# Altium schematic sheet Power Supplies.SchDoc
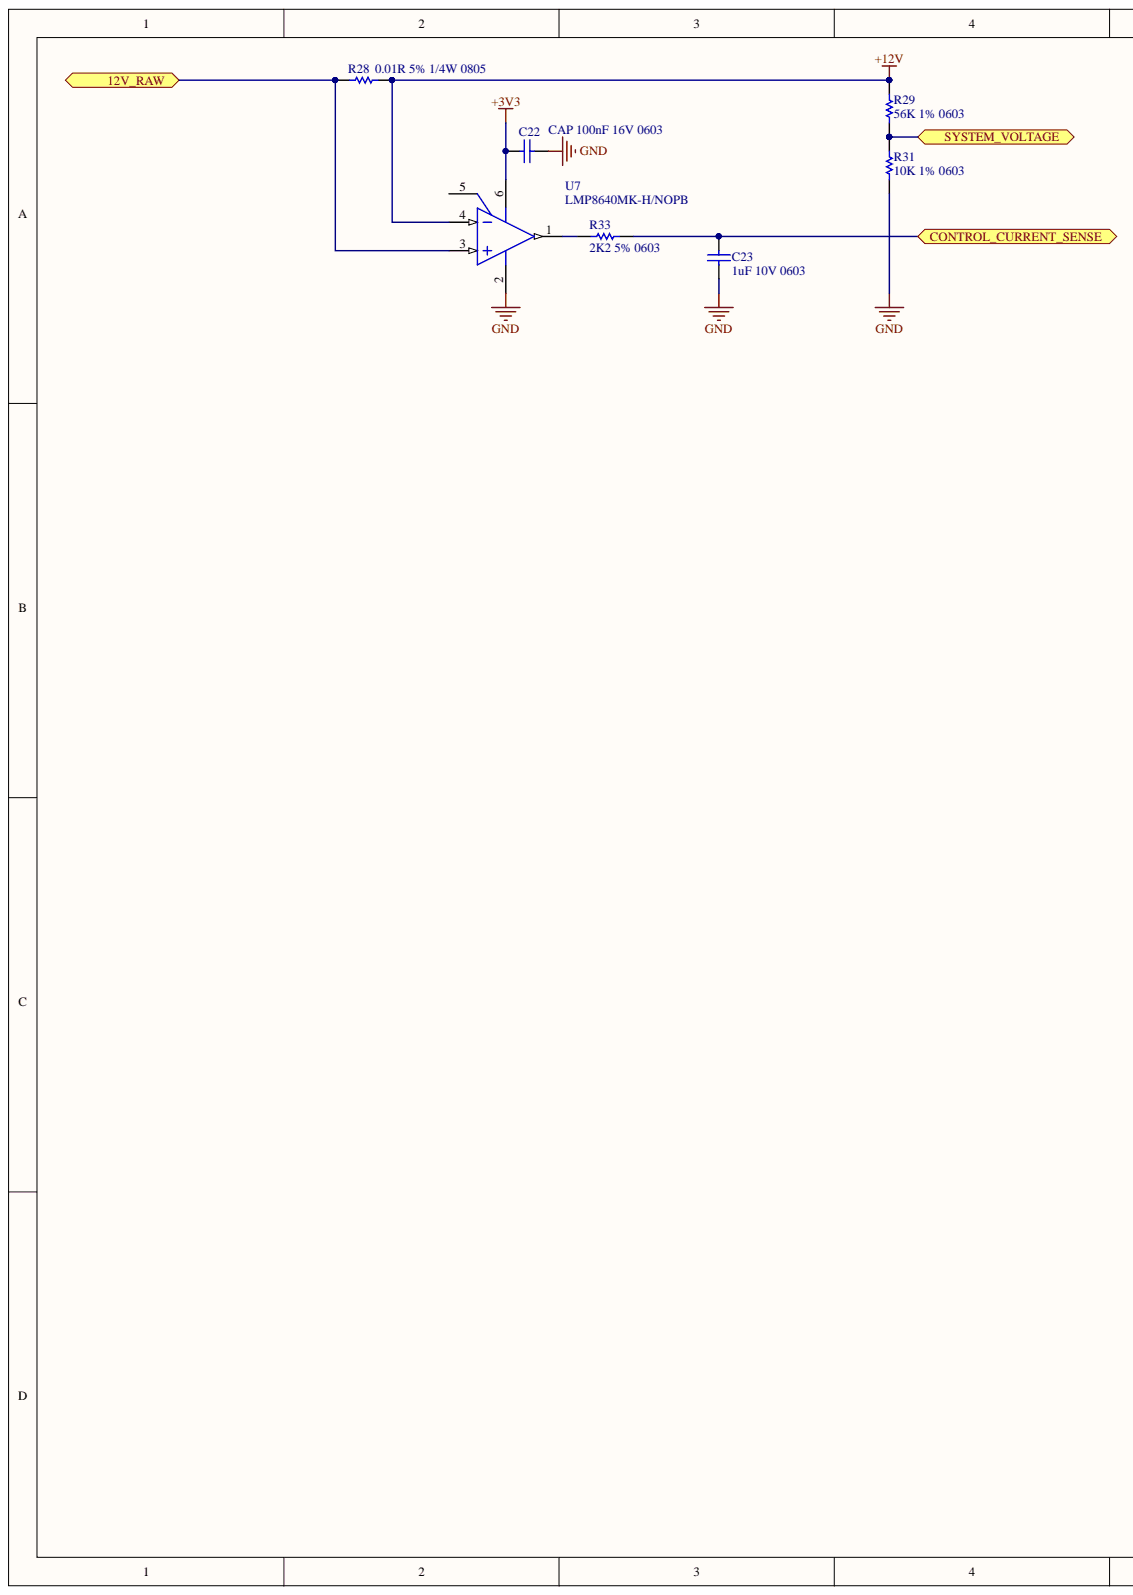
[diagram p0: Power Supplies.SchDoc, left]
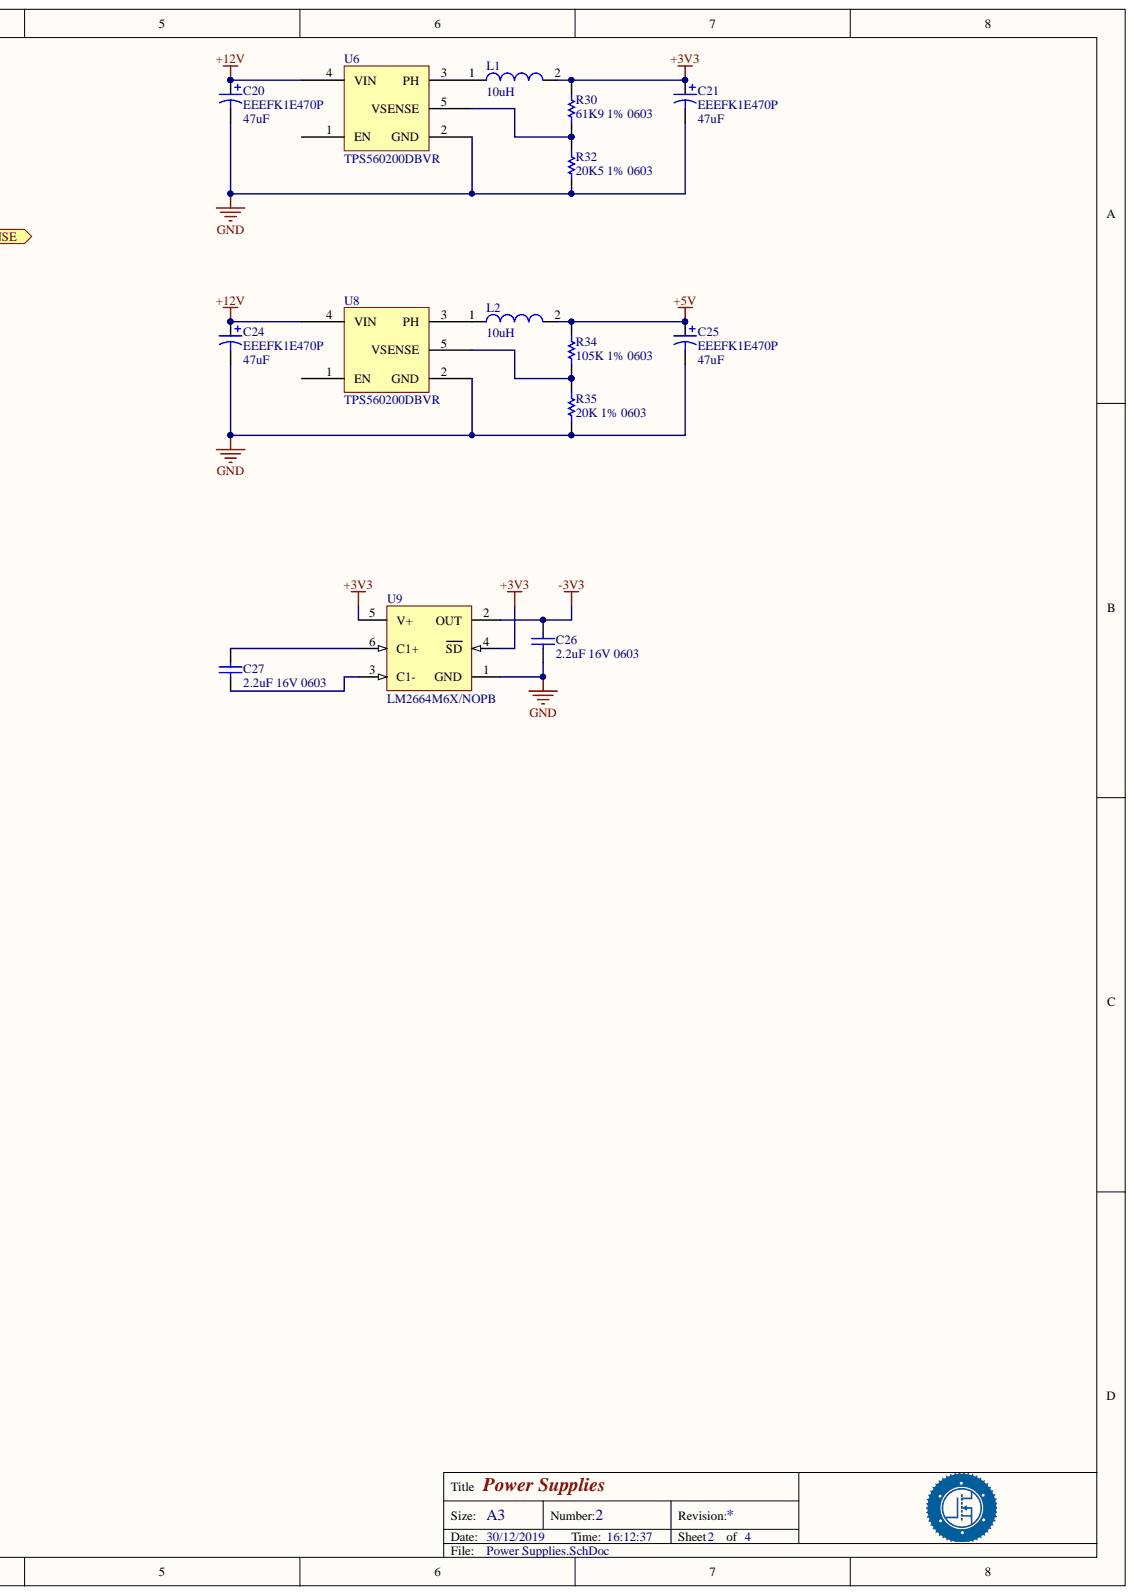
[diagram p1: Power Supplies.SchDoc, right]

## Parts
C20  EEEFK1E470P  value 47uF  [PANASONIC_ALU_CAP_D,D8]
C21  EEEFK1E470P  value 47uF  [PANASONIC_ALU_CAP_D,D8]
C22  CAP 100nF 16V 0603  value 100nF  [CAPC0603(1608)100_N]
C23  1uF 10V 0603  value 1uF  [CAPC0603(1608)100_N]
C24  EEEFK1E470P  value 47uF  [PANASONIC_ALU_CAP_D,D8]
C25  EEEFK1E470P  value 47uF  [PANASONIC_ALU_CAP_D,D8]
C26  2.2uF 16V 0603  value 2.2uF  [CAPC0603(1608)100_L]
C27  2.2uF 16V 0603  value 2.2uF  [CAPC0603(1608)100_L]
L1  Inductance: 10uH  [WE-PD-M-PB]  (hidden comment: 744777910)
L2  Inductance: 10uH  [WE-PD-M-PB]  (hidden comment: 744777910)
R28  0.01R 5% 1/4W 0805  Tolerance: 5%  [RESC0805(2012)_L]
R29  56K 1% 0603  [RESC0603(1608)_N]
R30  61K9 1% 0603  value 61K9  [RESC0603(1608)_N]
R31  10K 1% 0603  [RESC0603(1608)_N]
R32  20K5 1% 0603  value 20K5  [RESC0603(1608)_N]
R33  2K2 5% 0603  Case-EIA: 0603  [RESC0603(1608)_N]
R34  105K 1% 0603  [RESC0603(1608)_L]
R35  20K 1% 0603  [RESC0603(1608)_L]
U6  TPS560200DBVR  [SOT23-5]
U7  LMP8640MK-H/NOPB  [DDC0006A_L]
U8  TPS560200DBVR  [SOT23-5]
U9  LM2664M6X/NOPB  [DBV0006A_N]

## Ports
12V_RAW, CONTROL_CURRENT_SENSE, SYSTEM_VOLTAGE

## Nets
+3V3: C21.1 C22.1 L1.2 R30.2 U7.6 U9.4(SD) U9.5(V+)
+5V: C25.1 L2.2 R34.2
+12V: C20.1 C24.1 R28.2 R29.1 U6.4(VIN) U7.4 U8.4(VIN)
-3V3: C26.1 U9.2(OUT)
GND: C20.2 C21.2 C22.2 C23.2 C24.2 C25.2 C26.2 R31.2 R32.1 R35.2 U6.2(GND) U7.2 U8.2(GND) U9.1(GND)
12V_RAW: R28.1 U7.3 ; port 12V_RAW
CONTROL_CURRENT_SENSE: C23.1 R33.1 ; port CONTROL_CURRENT_SENSE
SYSTEM_VOLTAGE: R29.2 R31.1 ; port SYSTEM_VOLTAGE
NetC27_1: C27.1 U9.6(C1+)
NetC27_2: C27.2 U9.3(C1-)
NetL1_1: L1.1 U6.3(PH)
NetL2_1: L2.1 U8.3(PH)
NetR30_1: R30.1 R32.2 U6.5(VSENSE)
NetR33_2: R33.2 U7.1
NetR34_1: R34.1 R35.1 U8.5(VSENSE)

Not connected: U6 1(EN); U7 5; U8 1(EN)

## Library data of the parts
C20 C21 C24 C25 = Cap Pol3: Aluminum Electrolytic Capacitors (SMD Type); Manufacturer: Panasonic; Component Kind: Standard; LatestRevisionDate: 29-May-2009; LatestRevisionNote: IPC-7351 Footprint Added.; Library Name: Miscellaneous Devices.IntLib; PackageDescription: Chip Capacitor; PackageReference: C0805; PartNumber: EEEFK1E470P; Published: 8-Jun-2000; Publisher: Altium Limited
C22 = CMP-1035-02585-1: CAP 100nF 16V ±20% 0603 (1608 Metric) Thickness 1mm SMD; Case-EIA: 0603; Case-Metric: 1608; Tolerance: Â±20%; Component Kind: Standard; Library Name: Altium Content Vault; Max Thickness: 1 mm; Rated Voltage: 16 V; Technology: SMT
C23 = CMP-1035-02733-1: CAP 1uF 10V ±10% 0603 (1608 Metric) Thickness 1mm SMD; Case-EIA: 0603; Case-Metric: 1608; Tolerance: Â±10%; Component Kind: Standard; Library Name: Altium Content Vault; Max Thickness: 1 mm; Rated Voltage: 10 V; Technology: SMT
C26 C27 = CMP-1035-02763-1: CAP 2.2uF 16V ±10% 0603 (1608 Metric) Thickness 1mm SMD; Case-EIA: 0603; Case-Metric: 1608; Tolerance: Â±10%; Component Kind: Standard; Library Name: Altium Content Vault; Max Thickness: 1 mm; Rated Voltage: 16 V; Technology: SMT
L1 L2 = CMP-0227-00229-1: SMD-Shielded Power Inductor WE-PD, L = 10.0 µH; Component Kind: Standard; ComponentLink1Description: Manufacturer Link; ComponentLink1URL: http://www.we-online.com; ComponentLink2Description: Datasheet; ComponentLink2URL: http://www.we-online.de/katalog/media/pdf/744777910.pdf; DatasheetVersion: ver 10, Jan-2008; Library Name: Altium Content Vault; PackageDescription: SMD Inductor, 2-Leads, Body 7.3 x 7.3 mm; PackageReference: WE-PD-M-PB; PackageVersion: 2008
R28 = CMP-1591-00002-1: 10 mOhms ±5% 0.25W, 1/4W Chip Resistor 0805 (2012 Metric) Current Sense Thin Film; Case-EIA: 0805; Case-Metric: 2012; Value: 0.01 Ohms; Component Kind: Standard; Library Name: Altium Content Vault; PackageReference: RESC0805(2012); Power: 0.25W
R29 = CMP-1012-00708-1: 56K 0.1W 1% 0603 (1608 Metric)  SMD; Case-EIA: 0603; Case-Metric: 1608; Value: 56000.00 Ohm; Component Kind: Standard; Library Name: Altium Content Vault; Power: 0.1 W; Technology: SMT; Tolerance: 1 %
R30 = CMP-1012-00713-1: 61K9 0.1W 1% 0603 (1608 Metric)  SMD; Case-EIA: 0603; Case-Metric: 1608; Component Kind: Standard; Library Name: Altium Content Vault; Power: 0.1 W; Technology: SMT; Tolerance: 1 %
R31 = CMP-1012-00623-1: 10K 0.1W 1% 0603 (1608 Metric)  SMD; Case-EIA: 0603; Case-Metric: 1608; Value: 10000.00 Ohm; Component Kind: Standard; Library Name: Altium Content Vault; Power: 0.1 W; Technology: SMT; Tolerance: 1 %
R32 = CMP-1012-00656-1: 20K5 0.1W 1% 0603 (1608 Metric)  SMD; Case-EIA: 0603; Case-Metric: 1608; Component Kind: Standard; Library Name: Altium Content Vault; Power: 0.1 W; Technology: SMT; Tolerance: 1 %
R33 = CMP-1012-00082-1: 2K2 0.1W 5% 0603 (1608 Metric)  SMD; Case-Metric: 1608; Value: 2200.00 Ohm; Component Kind: Standard; Library Name: Altium Content Vault; Power: 0.1 W; Technology: SMT; Tolerance: 5 %
R34 = CMP-1012-00738-1: 105K 0.1W 1% 0603 (1608 Metric)  SMD; Case-EIA: 0603; Case-Metric: 1608; Value: 105000.00 Ohm; Component Kind: Standard; Library Name: Altium Content Vault; Power: 0.1 W; Technology: SMT; Tolerance: 1 %
R35 = CMP-1012-00655-1: 20K 0.1W 1% 0603 (1608 Metric)  SMD; Case-EIA: 0603; Case-Metric: 1608; Value: 20000.00 Ohm; Component Kind: Standard; Library Name: Altium Content Vault; Power: 0.1 W; Technology: SMT; Tolerance: 1 %
U6 U8 = TPS560200DBVR: 4.5-V to 17-V Input, 500-mA Synchronous Step-Down Converter With  Advanced Eco-Mode™; Manufacturer: Texas Instruments; Component Kind: Standard; Library Name: sl-altium.SchLib; Value: TPS560200DBVR
U7 = CMP-0055-01043-3: Precision High Voltage Current Sense Amplifier, 6 pin TSOT; Manufacturer: Texas Instruments; CompType: Amplifiers; PackageTopMarking: AE6A*XTT; PowerWise: N; Code_IPC: SOT26A-6; Code_JEDEC: MO-193-AA; Component Kind: Standard; ComponentLink1Description: Datasheet URL; ComponentLink1URL: http://www.ti.com/general/docs/lit/getliterature.tsp?genericPartNumber=LMP8640&fileType=pdf; Library Name: Altium Content Vault; PackageDescription: Molded TSOT, 2.97 x 1.65 x 0.87mm Body, 6 Lead, 0.95mm Pitch; Package Reference: DDC0006A; PinCount: 6; RoHS: Y
U9 = CMP-0065-00076-3: Switched Capacitor Voltage Converter, 6-pin SOT-23, Pb-Free; Manufacturer: Texas Instruments; CompType: Switch Caps; PackageTopMarking: S03A*XTT; PowerWise: N; Code_IPC: SOT26A-6; Code_JEDEC: MO-178-AB; Component Kind: Standard; ComponentLink1Description: Datasheet URL; ComponentLink1URL: http://www.ti.com/general/docs/lit/getliterature.tsp?genericPartNumber=LM2664&fileType=pdf; Library Name: Altium Content Vault; PackageDescription: Molded SOT-23, .115 x .063 x .040in Body, 6 Lead, .0375in in Pitch; PackageReference: DBV0006A; PinCount: 6; RoHS: Y
